# Altium schematic sheet SAM2695.SchDoc
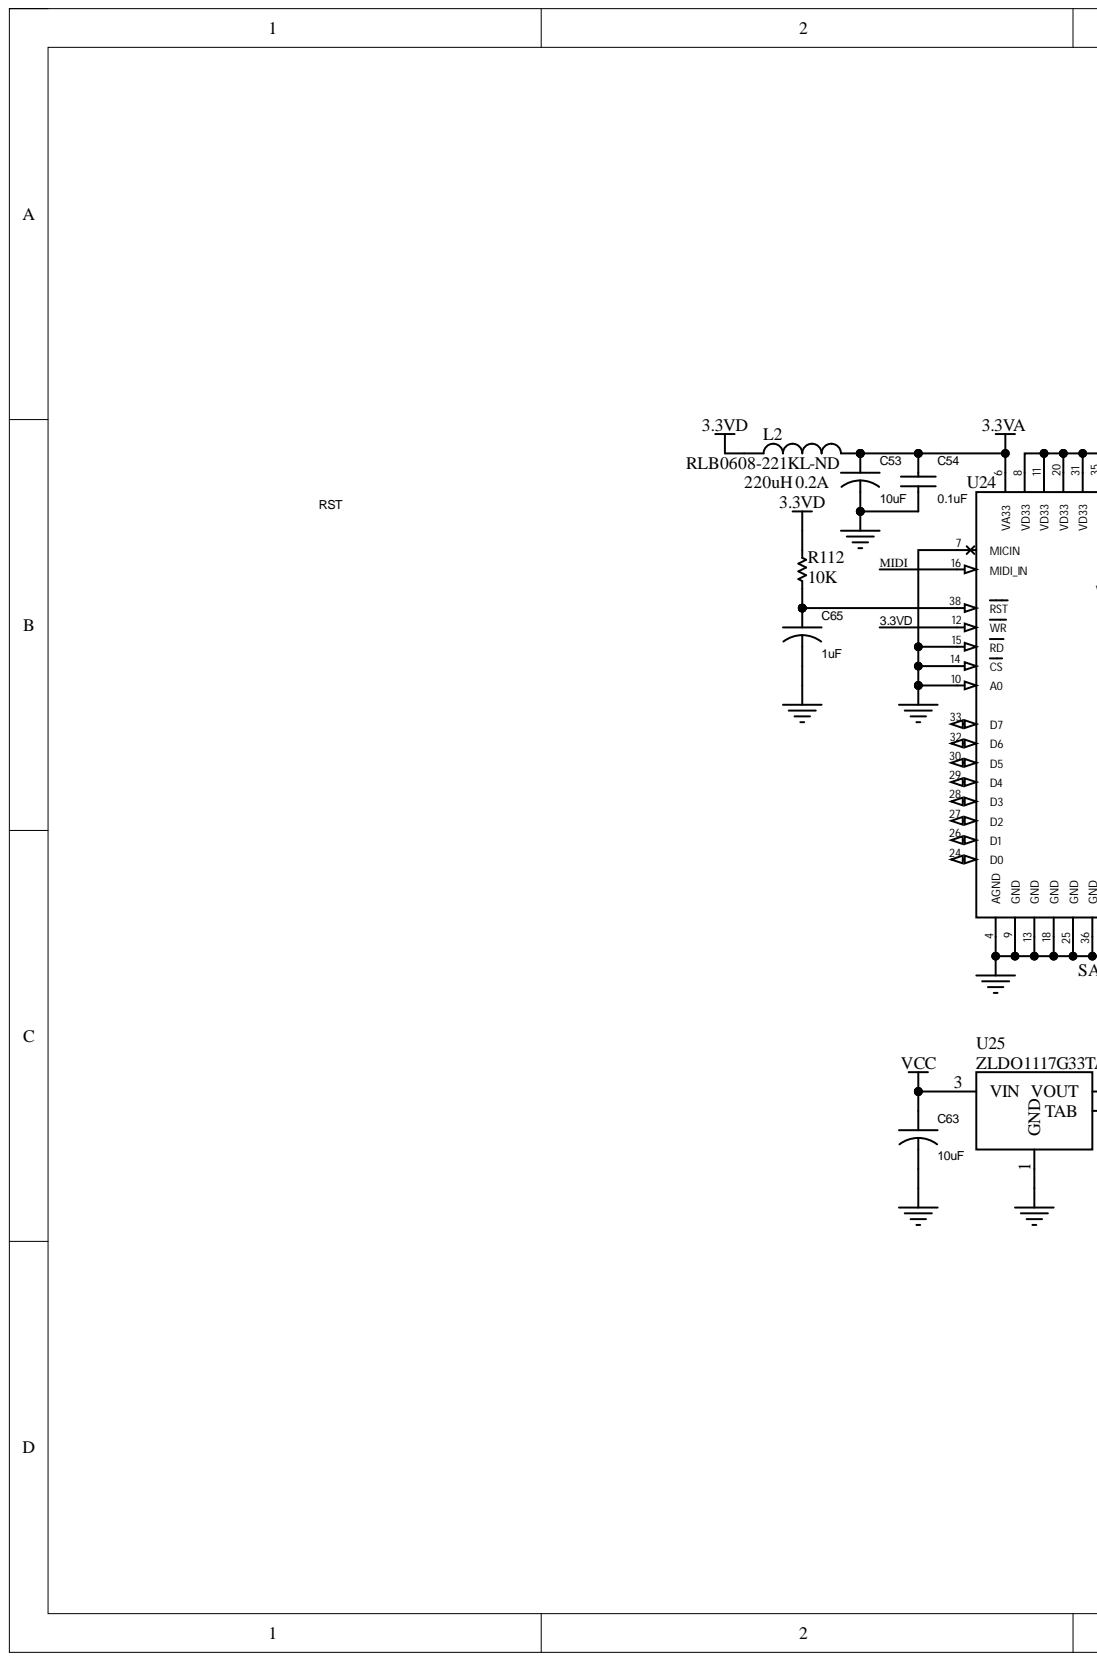
[diagram p0: SAM2695.SchDoc, left]
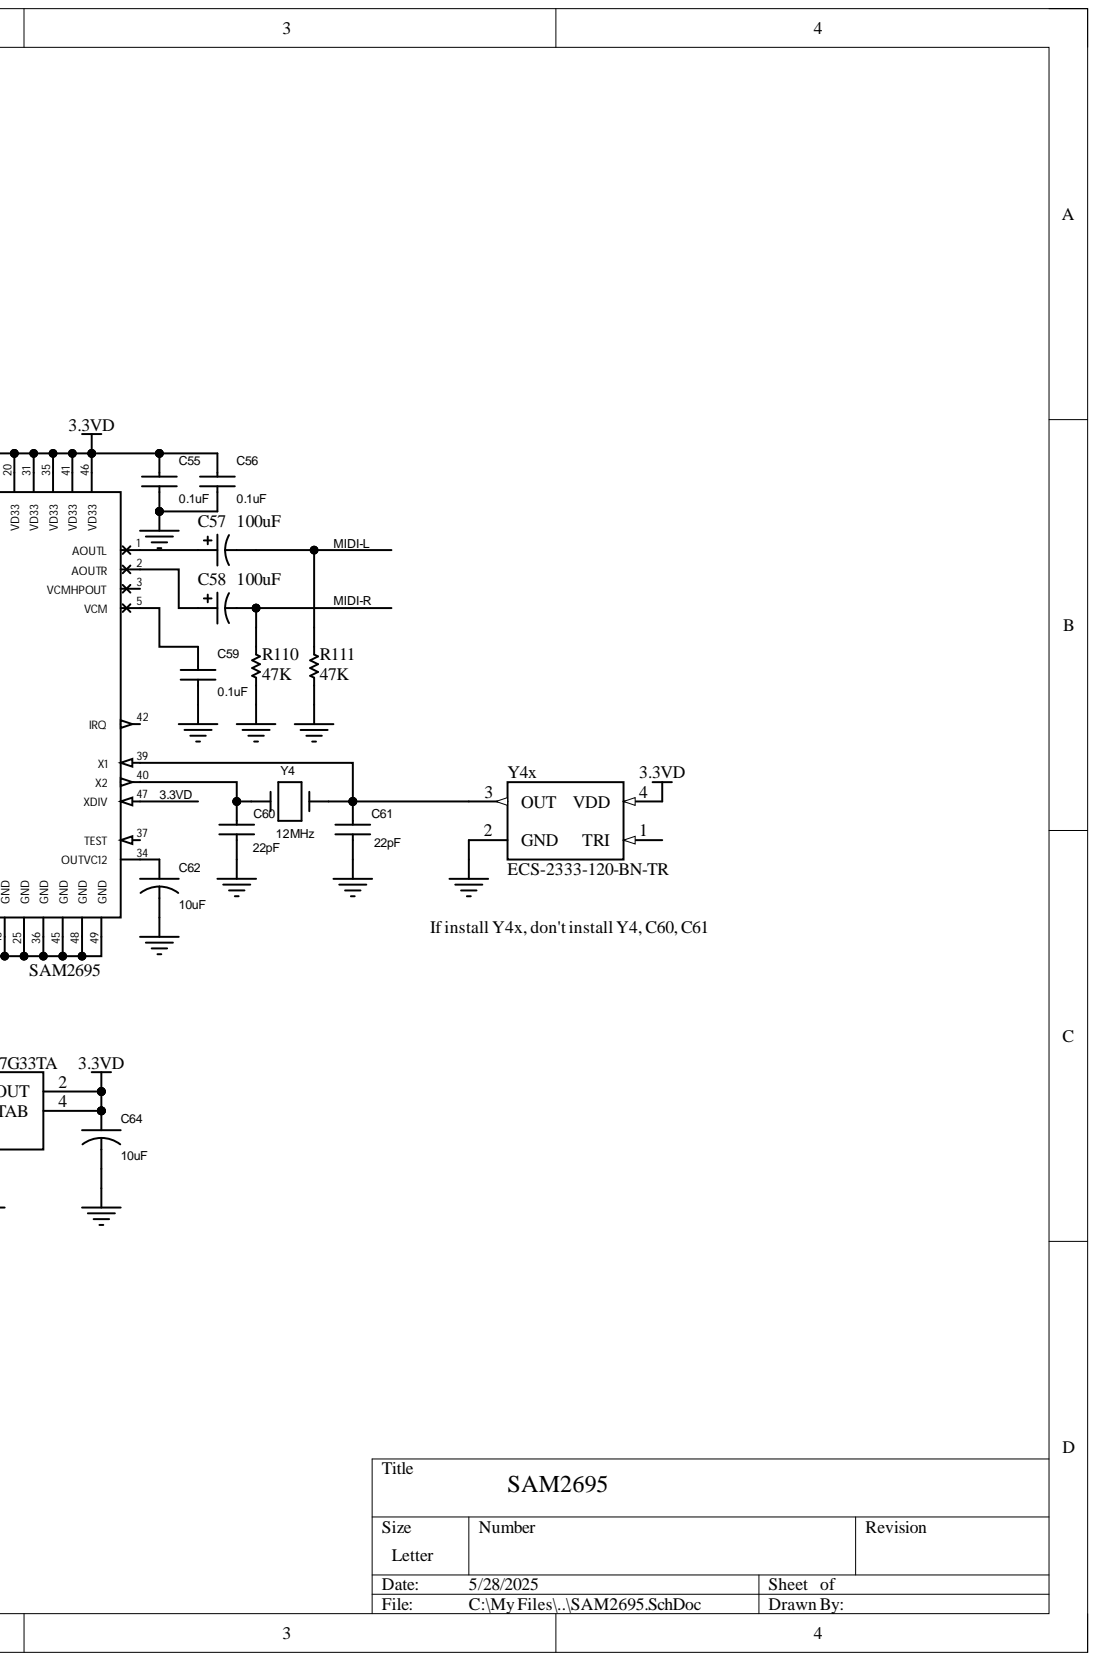
[diagram p1: SAM2695.SchDoc, right]

## Parts
C53  10uF  [CYL/D.150/LS.100/.031]
C54  0.1uF  [RAD/.200X.100/LS.100/.031]
C55  0.1uF  [RAD/.200X.100/LS.100/.031]
C56  0.1uF  [RAD/.200X.100/LS.100/.031]
C57  100uF  [CYL/D.150/LS.100/.031]
C58  100uF  [CYL/D.150/LS.100/.031]
C59  0.1uF  [RAD/.200X.100/LS.100/.031]
C60  22pF  [RAD/.200X.100/LS.100/.031]
C61  22pF  [RAD/.200X.100/LS.100/.031]
C62  10uF  [CYL/D.150/LS.100/.031]
C63  10uF  [CYL/D.150/LS.100/.031]
C64  10uF  [CYL/D.150/LS.100/.031]
C65  1uF  [CYL/D.150/LS.100/.031]
L2  RLB0608-221KL-ND  value 220uH 0.2A  [CYL/D.150/LS.100/.031]
R110  47K  [AX/.350X.100/.034]
R111  47K  [AX/.350X.100/.034]
R112  10K  [AX/.350X.100/.034]
U24  SAM2695  [QFN-48_L6.0-W6.0-P0.40-TL-EP4.2]
U25  ZLDO1117G33TA  [SM/SOT223]
Y4  12MHz  [RAD/.300X.125/LS.200/.031]
Y4x  ECS-2333-120-BN-TR  [OSC_ECS-2333-120-BN-TR]

## Nets
3.3VA: C53.1 C54.1 L2.2 U24.6(VA33)
GND: C53.2 C54.2 C55.2 C56.2 C59.2 C60.2 C61.2 C62.2 C63.2 C64.2 C65.2 R110.1 R111.1 U24.4(AGND) U24.7(MICIN) U24.9(GND) U24.10(A0) U24.13(GND) U24.14(CS) U24.15(RD) U24.18(GND) U24.25(GND) U24.36(GND) U24.45(GND) U24.48(GND) U24.49(GND) U25.1(GND) Y4x.2(GND)
VCC: C63.1 U25.3(VIN)
3.3VD: C55.1 C56.1 C64.1 L2.1 R112.2 U24.8(VD33) U24.11(VD33) U24.12(WR) U24.20(VD33) U24.31(VD33) U24.35(VD33) U24.41(VD33) U24.46(VD33) U24.47(XDIV) U25.2(VOUT) U25.4(TAB) Y4x.4(VDD)
MIDI: U24.16(MIDI_IN)
MIDI-L: C57.2 R111.2
MIDI-R: C58.2 R110.2
NetC57_1: C57.1 U24.1(AOUTL)
NetC58_1: C58.1 U24.2(AOUTR)
NetC59_1: C59.1 U24.5(VCM)
NetC60_1: C60.1 U24.40(X2) Y4.2
NetC61_1: C61.1 U24.39(X1) Y4.1 Y4x.3(OUT)
NetC62_1: C62.1 U24.34(OUTVC12)
NetC65_1: C65.1 R112.1 U24.38(RST)
RST:

Not connected: U24 3(VCMHPOUT) 24(D0) 26(D1) 27(D2) 28(D3) 29(D4) 30(D5) 32(D6) 33(D7) 37(TEST) 42(IRQ); Y4x 1(TRI)

## Library data of the parts
C53 C62-C65 = C: COMPFIXED: NO; COMPGROUP: 0; COMPHEIGHT: 0.000; COMPLOC: [875.000,4250.000]; COMPROT: 90; COMPSIDE: TOP; COMPKEY: NO; COMPLOCKED: NO; Component Kind: Standard; DECOUPLER: NO; MEMORY: NO
C54-C56 C59 = CAP NP: COMPFIXED: NO; COMPGROUP: 0; COMPHEIGHT: 0.000; COMPLOC: [3150.317,4300.317]; COMPROT: 180; COMPSIDE: TOP; COMPKEY: NO; COMPLOCKED: NO; Component Kind: Standard; DECOUPLER: NO; MEMORY: NO
C57 C58 = Cap Pol1: Polarized Capacitor (Radial); Component Kind: Standard; LatestRevisionDate: 17-Jul-2002; LatestRevisionNote: Re-released for DXP Platform.; Library Name: Miscellaneous Devices.IntLib; PackageReference: RB7.6-15; Published: 8-Jun-2000; Signal Integrity: Cap Pol; Simulation: CAP; Value: 100uF; Publisher: Altium Limited
C60 C61 = CAP NP: COMPFIXED: NO; COMPGROUP: 0; COMPHEIGHT: 0.000; COMPLOC: [6275.000,975.000]; COMPSIDE: TOP; FPLIST: RAD/.150X.100/LS.100/.031; COMPKEY: NO; COMPLOCKED: NO; Component Kind: Standard; COMPROT: 0; DECOUPLER: NO; MEMORY: NO
L2 = Inductor: Code_IEC: 1005; Value: 220uH 0.2A; Code_JEITA: 0402; Component Kind: Standard; Description: Inductor; LatestRevisionDate: 29-May-2009; LatestRevisionNote: IPC-7351 Footprint Added.; Library Name: Miscellaneous Devices.IntLib; PackageDescription: Chip Inductor; PackageReference: 0402-A; Published: 8-Jun-2000; Signal Integrity: Inductor; Simulation: INDUCTOR; Publisher: Altium Limited
R110-R112 = Res1: Resistor; Component Kind: Standard; LatestRevisionDate: 17-Jul-2002; LatestRevisionNote: Re-released for DXP Platform.; Library Name: Miscellaneous Devices.IntLib; PackageReference: AXIAL-0.3; Published: 8-Jun-2000; Signal Integrity: Res; Simulation: RESISTOR; Publisher: Altium Limited
  R110 R111: Value: 47K
  R112: Value: 10K
U25 = REGULATOR-1_0: COMPFIXED: NO; COMPGROUP: 0; COMPHEIGHT: 0.000; COMPLOC: [2750.000,725.000]; COMPSIDE: BOT; COMPKEY: NO; COMPLOCKED: NO; Component Kind: Standard; COMPROT: 0; DECOUPLER: NO; MEMORY: NO
Y4 = CRYSTAL: COMPFIXED: NO; COMPGROUP: 0; COMPHEIGHT: 0.000; COMPLOC: [6575.317,975.317]; COMPSIDE: TOP; COMPKEY: NO; COMPLOCKED: NO; Component Kind: Standard; COMPROT: 0; DECOUPLER: NO; MEMORY: NO
Y4x = ECS-2333-120-BN-TR: subminiature SMD oscillators.; Availability: Unavailable; Component Kind: Standard; MP: ECS-2333-120-BN-TR; Package: None; Price: None; Library Name: Retro Computer.SchLib
U24 = SAM2695: Component Kind: Standard; Library Name: Retro Computer.SchLib
